# Altium schematic sheet cpuinterface.SchDoc(cpuinterface)
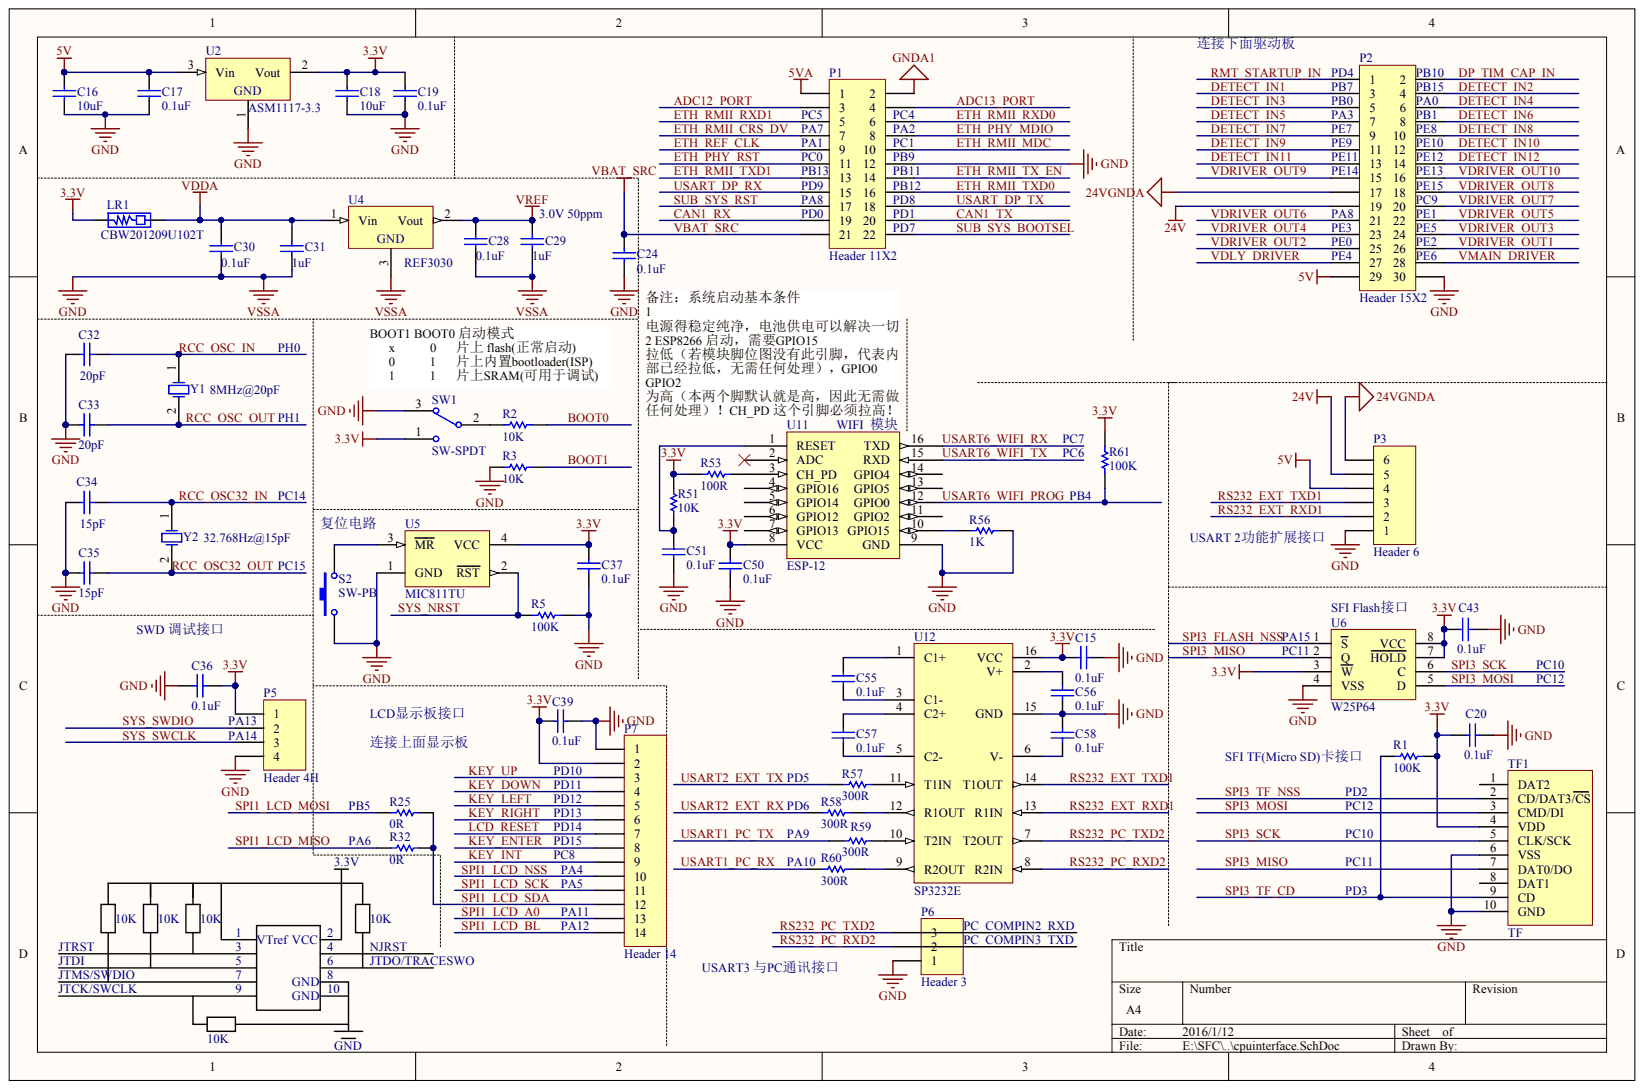
[diagram p0: cpuinterface.SchDoc]

## Parts
C15  0.1uF
C16  10uF
C17  0.1uF
C18  10uF
C19  0.1uF
C20  0.1uF
C24  0.1uF
C28  0.1uF
C29  1uF
C30  0.1uF
C31  1uF
C32  20pF
C33  20pF
C34  15pF
C35  15pF
C36  0.1uF
C37  0.1uF
C39  0.1uF
C43  0.1uF
C50  0.1uF
C51  0.1uF
C55  0.1uF
C56  0.1uF
C57  0.1uF
C58  0.1uF
LR1  CBW201209U102T
P1  Header 11X2
P2  Header 15X2
P3  Header 6
P5  Header 4H
P6  Header 3
P7  Header 14
R1  100K
R2  10K
R3  10K
R5  100K
R25  0R
R32  0R  value 10K
R51  10K
R53  100R
R56  1K
R57  300R
R58  300R
R59  300R
R60  300R
R61  100K
S2  SW-PB
SW1  SW-SPDT
TF1  TF
U2  ASM1117-3.3
U4  REF3030
U5  MIC811TU
U6  W25P64
U11  ESP-12
U12  SP3232E
Y1  8MHz@20pF
Y2  32.768Hz@15pF

## Nets
3.3V: C15.2 C18.2 C19.2 C20.1 C36.2 C37.2 C39.2 C43.1 C50.1 LR1.1 P5.1 P7.2 R1.2 R51.1 R53.1 R61.1 SW1.1 TF1.4(VDD) U2.2 U5.4(VCC) U6.3(W) U6.7(HOLD) U6.8(VCC) U11.8(VCC) U12.16(VCC)
5V: C16.2 C17.2 P2.29 P3.4 U2.3
5VA: P1.1
24V: P2.19 P3.5
24VGNDA: P2.17 P3.6
GND: C15.1 C16.1 C17.1 C18.1 C19.1 C20.2 C24.2 C28.1 C29.1 C30.1 C31.1 C32.2 C33.2 C34.2 C35.2 C36.1 C37.1 C39.1 C43.2 C50.2 C51.2 C56.1 C58.2 P1.12 P2.30 P3.1 P5.4 P6.1 P7.1 R3.2 R5.2 R56.1 S2.1 SW1.3 TF1.6(VSS) TF1.10(GND) U2.1 U4.3 U5.1(GND) U6.4(VSS) U11.9(GND) U12.15(GND)
GNDA1: P1.2
VDDA: C30.2 C31.2 LR1.2 U4.1
VREF: C28.2 C29.2 U4.2
ADC12_PORT: P1.3
ADC13_PORT: P1.4
BOOT0: R2.1
BOOT1: R3.1
CAN1_RX: P1.19
CAN1_TX: P1.20
DETECT_IN1: P2.3
DETECT_IN2: P2.4
DETECT_IN3: P2.5
DETECT_IN4: P2.6
DETECT_IN5: P2.7
DETECT_IN6: P2.8
DETECT_IN7: P2.9
DETECT_IN8: P2.10
DETECT_IN9: P2.11
DETECT_IN10: P2.12
DETECT_IN11: P2.13
DETECT_IN12: P2.14
DP_TIM_CAP_IN: P2.2
ETH_PHY_MDIO: P1.8
ETH_PHY_RST: P1.11
ETH_REF_CLK: P1.9
ETH_RMII_CRS_DV: P1.7
ETH_RMII_MDC: P1.10
ETH_RMII_RXD0: P1.6
ETH_RMII_RXD1: P1.5
ETH_RMII_TX_EN: P1.14
ETH_RMII_TXD0: P1.16
ETH_RMII_TXD1: P1.13
KEY_DOWN: P7.4
KEY_ENTER: P7.8
KEY_INT: P7.9
KEY_LEFT: P7.5
KEY_RIGHT: P7.6
KEY_UP: P7.3
LCD_RESET: P7.7
RCC_OSC32_IN: C34.1 Y2.1
RCC_OSC32_OUT: C35.1 Y2.2
RCC_OSC_IN: C32.1 Y1.1
RCC_OSC_OUT: C33.1 Y1.2
RMT_STARTUP_IN: P2.1
RS232_EXT_RXD1: P3.2 U12.13(R1IN)
RS232_EXT_TXD1: P3.3 U12.14(T1OUT)
RS232_PC_RXD2: P6.2 U12.8(R2IN)
RS232_PC_TXD2: P6.3 U12.7(T2OUT)
SPI1_LCD_A0: P7.13
SPI1_LCD_BL: P7.14
SPI1_LCD_MISO: R32.1
SPI1_LCD_MOSI: R25.1
SPI1_LCD_NSS: P7.10
SPI1_LCD_SCK: P7.11
SPI1_LCD_SDA: P7.12 R25.2 R32.2
SPI3_FLASH_NSS: U6.1(S)
SPI3_MISO: TF1.7(DAT0/DO) U6.2(Q)
SPI3_MOSI: TF1.3(CMD/DI) U6.5(D)
SPI3_SCK: TF1.5(CLK/SCK) U6.6(C)
SPI3_TF_CD: R1.1 TF1.9(CD)
SPI3_TF_NSS: TF1.2(CD/DAT3/CS)
SUB_SYS_BOOTSEL: P1.22
SUB_SYS_RST: P1.17
SYS_NRST: R5.1 U5.2(RST)
SYS_SWCLK: P5.3
SYS_SWDIO: P5.2
USART1_PC_RX: R60.2
USART1_PC_TX: R59.1
USART2_EXT_RX: R58.1
USART2_EXT_TX: R57.1
USART6_WIFI_PROG: R61.2 U11.12(GPIO0)
USART6_WIFI_RX: U11.16(TXD)
USART6_WIFI_TX: U11.15(RXD)
USART_DP_RX: P1.15
USART_DP_TX: P1.18
VBAT_SRC: C24.1 P1.21
VDLY_DRIVER: P2.27
VDRIVER_OUT1: P2.26
VDRIVER_OUT2: P2.25
VDRIVER_OUT3: P2.24
VDRIVER_OUT4: P2.23
VDRIVER_OUT5: P2.22
VDRIVER_OUT6: P2.21
VDRIVER_OUT7: P2.20
VDRIVER_OUT8: P2.18
VDRIVER_OUT9: P2.15
VDRIVER_OUT10: P2.16
VMAIN_DRIVER: P2.28
NetC51_1: C51.1 R51.2 U11.1(RESET)
NetC55_1: C55.1 U12.1(C1+)
NetC55_2: C55.2 U12.3(C1-)
NetC56_2: C56.2 U12.2(V+)
NetC57_1: C57.1 U12.5(C2-)
NetC57_2: C57.2 U12.4(C2+)
NetC58_1: C58.1 U12.6(V-)
NetR2_2: R2.2 SW1.2
NetR53_2: R53.2 U11.3(CH_PD)
NetR56_2: R56.2 U11.10(GPIO15)
NetR57_2: R57.2 U12.11(T1IN)
NetR58_2: R58.2 U12.12(R1OUT)
NetR59_2: R59.2 U12.10(T2IN)
NetR60_1: R60.1 U12.9(R2OUT)
NetS2_2: S2.2 U5.3(MR)

Not connected: TF1 1(DAT2) 8(DAT1); U11 2(ADC) 4(GPIO16) 5(GPIO14) 6(GPIO12) 7(GPIO13) 11(GPIO2) 13(GPIO5) 14(GPIO4)

## Library data of the parts
C15-C20 C24 C28-C31 C36 C37 C39 C43 C50 C51 C55-C58 = (no symbol name): Value: 1nF; Published: 8-Jun-2000; LatestRevisionDate: 17-Jul-2002; LatestRevisionNote: Re-released for DXP Platform.; PackageReference: RAD-0.3; Publisher: Altium Limited
C32-C35 = (no symbol name): Value: 100pF; Published: 8-Jun-2000; LatestRevisionDate: 17-Jul-2002; LatestRevisionNote: Re-released for DXP Platform.; PackageReference: RAD-0.3; Publisher: Altium Limited
R1 R5 R25 R53 R56-R61 = (no symbol name): Value: 10K; Published: 8-Jun-2000; LatestRevisionDate: 17-Jul-2002; LatestRevisionNote: Re-released for DXP Platform.; PackageReference: AXIAL-0.3; Publisher: Altium Limited
LR1 P1-P3 P5-P7 R2 R3 R32 R51 S2 SW1 TF1 U2 U4-U6 U11 U12 Y1 Y2 = (no symbol name)
  P1-P3 P5-P7: LatestRevisionDate: 17-Jul-2002; LatestRevisionNote: Re-released for DXP Platform.
  R2 R3 R51: Published: 8-Jun-2000; LatestRevisionDate: 17-Jul-2002; LatestRevisionNote: Re-released for DXP Platform.; PackageReference: AXIAL-0.3; Value: 10K
  R32: Published: 8-Jun-2000; LatestRevisionDate: 17-Jul-2002; LatestRevisionNote: Re-released for DXP Platform.; PackageReference: AXIAL-0.3
  S2: Published: 24-Mar-1999; LatestRevisionDate: 17-Jul-2002; LatestRevisionNote: Re-released for DXP Platform.; PackageReference: SPST-2
  SW1: Published: 24-Mar-1999; LatestRevisionDate: 17-Dec-2003; LatestRevisionNote: Schematic revised, PCB Footprint replaced.; DatasheetDocument: 05-Dec-2000; PackageReference: TL36WW15050; ComponentLink1Description: Manufacturer Link; ComponentLink1URL: http://www.apem.com
  U5: Published: 16-Jan-2001; LatestRevisionDate: 29-May-2009; LatestRevisionNote: IPC-7351 Footprint Added.; DatasheetVersion: Feb-1998; PackageVersion: Feb-1998; PackageReference: SOT143; PackageDescription: 4-Pin SOT-143 Package 1.9 mm Pitch, 2.3 mm Lead Span; ComponentLink1Description: Manufacturer Link; ComponentLink1URL: http://www.maxim-ic.com/; ComponentLink2Description: Datasheet; ComponentLink2URL: http://pdfserv.maxim-ic.com/en/ds/MAX811-MAX812.pdf
  U12: Published: 16-Jan-2001; LatestRevisionDate: 13-Apr-2006; LatestRevisionNote: IPC-7351 Footprint Added.; PackageReference: NSO16; PackageDescription: 16-Pin Small Outline Integrated Circuit 1.27 mm Pitch; Code_JEDEC: MS-012-AC; Code_IPC: SOIC127P600-16; ComponentLink1Description: Manufacturer Link; ComponentLink1URL: http://www.maxim-ic.com/; ComponentLink2Description: Datasheet; ComponentLink2URL: http://pdfserv.maxim-ic.com/en/ds/MAX220-MAX249.pdf
  Y1 Y2: Published: 8-Jun-2000; LatestRevisionDate: 17-Jul-2002; LatestRevisionNote: Re-released for DXP Platform.; PackageReference: R38
  P1-P3 P5-P7 R2 R3 R32 R51 S2 SW1 U5 U12 Y1 Y2: Publisher: Altium Limited
